# Altium schematic sheet Schematic.SchDoc(Schematic)
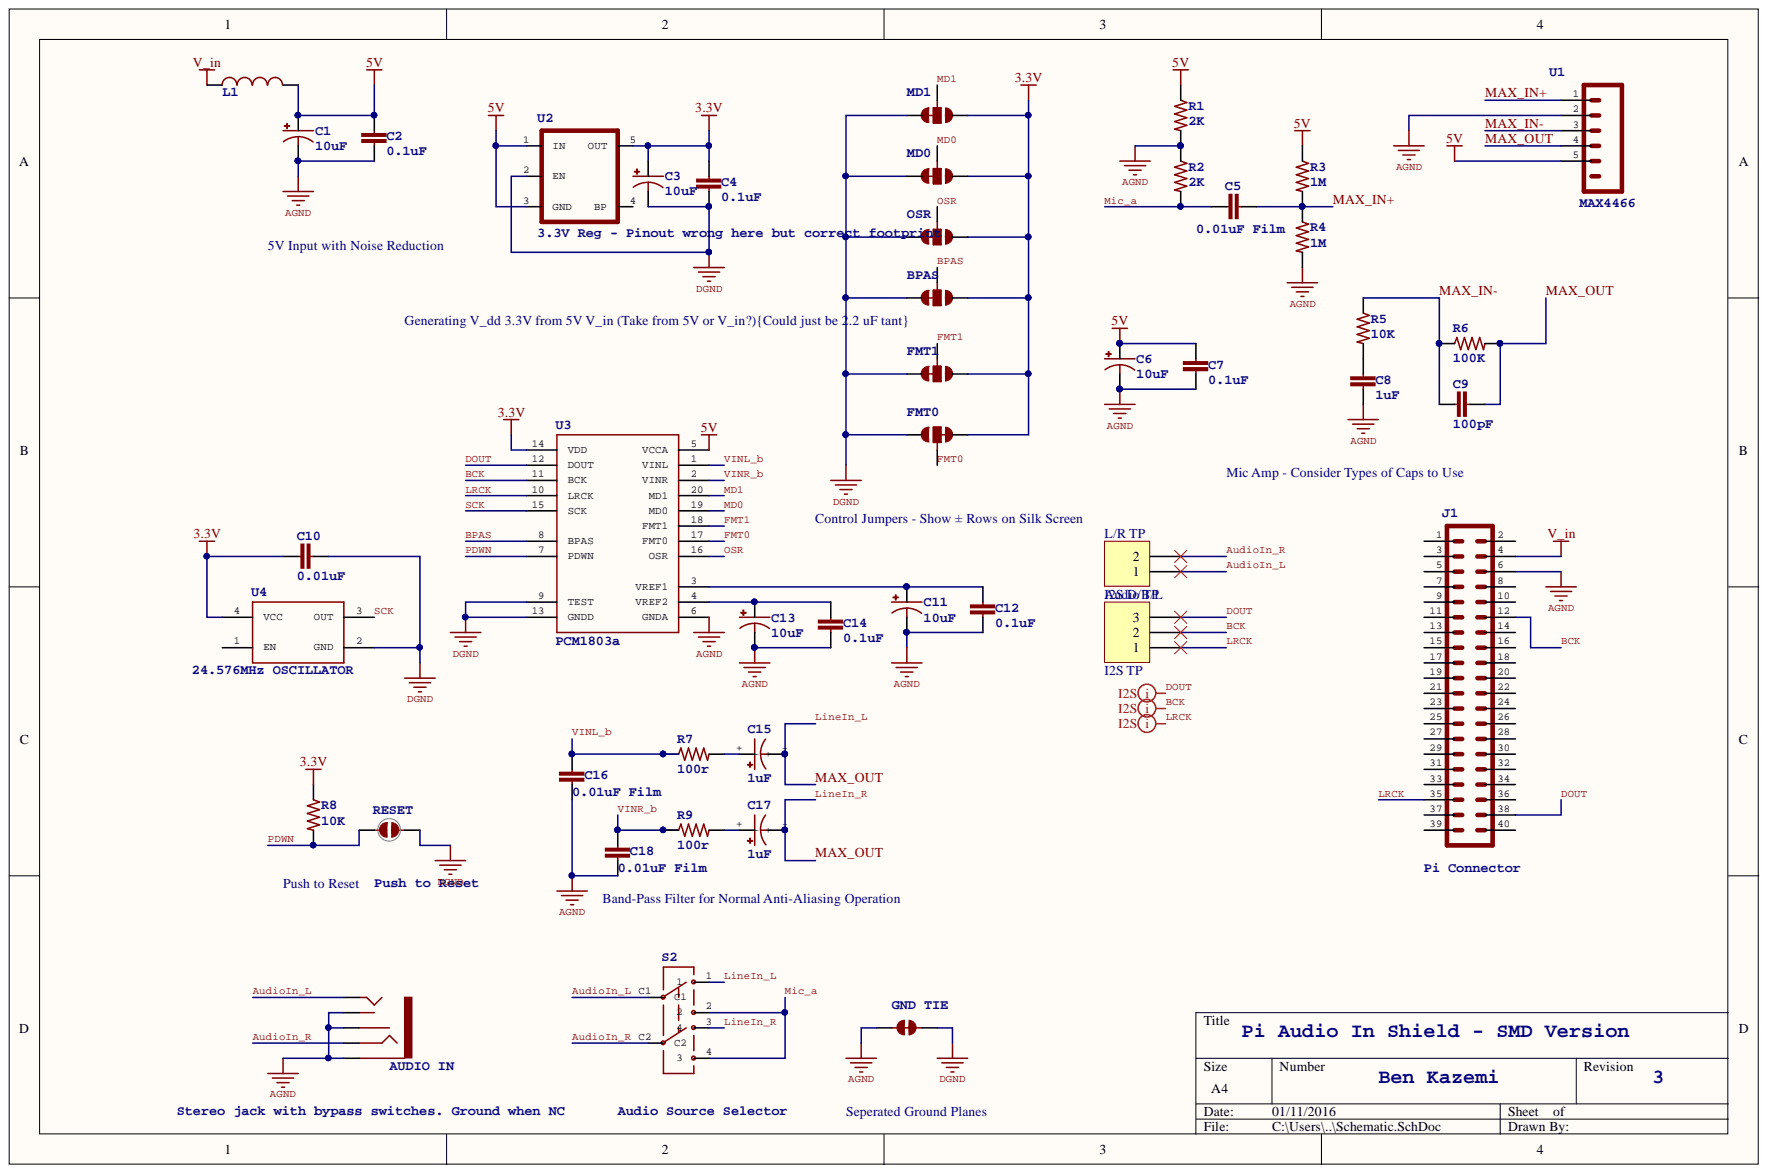
[diagram p0: Schematic.SchDoc]

## Parts
AUDIO_IN  Stereo jack with bypass switches. Ground when NC  [AUDIO-JACK]
BPAS  (no value)  [PAD-JUMPER-3-2OF3_NC_BY_TRACE_YES_SILK_FULL_BOX]
C1  10uF  [EIA3216]
C2  0.1uF  [0603-CAP]
C3  10uF  [EIA3216]
C4  0.1uF  [0603-CAP]
C5  0.01uF Film  [WIMA PCM 10]
C6  10uF  [EIA3216]
C7  0.1uF  [0603-CAP]
C8  1uF  [0603-CAP]
C9  100pF  [0603-CAP]
C10  0.01uF  [0603-CAP]
C11  10uF  [EIA3216]
C12  0.1uF  [0603-CAP]
C13  10uF  [EIA3216]
C14  0.1uF  [0603-CAP]
C15  1uF  [PANASONIC_B_ELECTRO]
C16  0.01uF Film  [WIMA PCM 10]
C17  1uF  [PANASONIC_B_ELECTRO]
C18  0.01uF Film  [WIMA PCM 10]
FMT0  (no value)  [PAD-JUMPER-3-2OF3_NC_BY_TRACE_YES_SILK_FULL_BOX]
FMT1  (no value)  [PAD-JUMPER-3-2OF3_NC_BY_TRACE_YES_SILK_FULL_BOX]
GND_TIE  JUMPER-PAD-2-NC_BY_TRACE  [PAD-JUMPER-2-NC_BY_TRACE_YES_SILK]  (value not drawn)
I2S_D/B/L  I2S TP  [HDR1X3]
J1  Pi Connector  [2X20]
L1  (no value)  [0805]
L/R_TP  Audio TP  [HDR1X2]
MD0  (no value)  [PAD-JUMPER-3-2OF3_NC_BY_TRACE_YES_SILK_FULL_BOX]
MD1  (no value)  [PAD-JUMPER-3-2OF3_NC_BY_TRACE_YES_SILK_FULL_BOX]
OSR  (no value)  [PAD-JUMPER-3-2OF3_NC_BY_TRACE_YES_SILK_FULL_BOX]
R1  2K  [0805]
R2  2K  [0805]
R3  1M  [0805]
R4  1M  [0805]
R5  10K  [0603-RES]
R6  100K  [0603-RES]
R7  100r  [0805]
R8  10K  [0603-RES]
R9  100r  [0805]
RESET  Push to Reset  [PAD-JUMPER-2-NC_BY_PASTE_YES_SILK_FULL_BOX]
S2  Audio Source Selector  [Switch DPDT MCS221N-2017]
U1  MAX4466  [SC70]
U2  3.3V Reg - Pinout wrong here but correct footprint  [SOT23-5]
U3  PCM1803a  [SSOP20]
U4  24.576MHz OSCILLATOR  [CRYSTAL-SMD-7.5X5.2-6PIN]

## Nets
3.3V: BPAS.1 C3.A C4.1 C10.1 FMT0.3 FMT1.1 MD0.1 MD1.1 OSR.1 R8.1 U2.5(OUT) U3.14(VDD) U4.4(VCC)
5V: C1.A C2.1 C6.A C7.1 L1.2 R1.1 R3.1 U1.5 U2.1(IN) U2.3(GND) U3.5(VCCA)
AGND: AUDIO_IN.RSH AUDIO_IN.SLEEVE AUDIO_IN.TSH C1.C C2.2 C6.C C7.2 C8.2 C11.C C12.2 C13.C C14.2 C16.2 C18.2 GND_TIE.1 J1.6 R1.2 R2.1 R4.2 U1.2 U3.6(GNDA)
DGND: BPAS.3 C3.C C4.2 C10.2 FMT0.1 FMT1.3 GND_TIE.2 MD0.3 MD1.3 OSR.3 RESET.2 U2.2(EN) U3.9(TEST) U3.13(GNDD) U4.2(GND)
V_IN: J1.4 L1.1
AUDIOIN_L: AUDIO_IN.TIP L/R_TP.1 S2.C1
AUDIOIN_R: AUDIO_IN.RING L/R_TP.2 S2.C2
BCK: I2S_D/B/L.2 J1.12 U3.11(BCK)
BPAS: BPAS.2 U3.8(BPAS)
DOUT: I2S_D/B/L.3 J1.38 U3.12(DOUT)
FMT0: FMT0.2 U3.17(FMT0)
FMT1: FMT1.2 U3.18(FMT1)
LINEIN_L: C9.2 C15.- C17.- R6.2 S2.1 S2.3 U1.4
LRCK: I2S_D/B/L.1 J1.35 U3.10(LRCK)
MAX_IN+: C5.2 R3.2 R4.1 U1.1
MAX_IN-: C9.1 R5.1 R6.1 U1.3
MD0: MD0.2 U3.19(MD0)
MD1: MD1.2 U3.20(MD1)
MIC_A: C5.1 R2.2 S2.2 S2.4
OSR: OSR.2 U3.16(OSR)
PDWN: R8.2 RESET.1 U3.7(PDWN)
SCK: U3.15(SCK) U4.3(OUT)
VINL_B: C16.1 R7.1 U3.1(VINL)
VINR_B: C18.1 R9.1 U3.2(VINR)
NetC8_1: C8.1 R5.2
NetC11_A: C11.A C12.1 U3.3(VREF1)
NetC13_A: C13.A C14.1 U3.4(VREF2)
NetC15_+: C15.+ R7.2
NetC17_+: C17.+ R9.2

Not connected: J1 1 2 3 5 7 8 9 10 11 13 14 15 16 17 18 19 20 21 22 23 24 25 26 27 28 29 30 31 32 33 34 36 37 39 40; U2 4(BP); U4 1(EN)

## Library data of the parts
AUDIO_IN = AUDIO-JACK2PTH: PROD_ID: CONN-08774; Component Kind: Standard; Library Name: SparkFun-Connectors.IntLib
BPAS FMT0 FMT1 MD0 MD1 OSR = JUMPER-PAD-3-2OF3_NC_BY_TRACE_SMALL: Component Kind: Net Tie; Description: JUMPER-PAD-3-2OF3_NC_BY_TRACE_SMALL; Library Name: SparkFun-Passives.IntLib
C1 C3 C6 C11 C13 = CAP_POL1206: Capacitor PolarizedThese are standard SMD and PTH capacitors. Normally 10uF, 47uF, and 100uF in electrolytic and tantalum varieties. Always verify the external diameter of the through hole cap, it varies with capacity, voltage, and manufacturer. The EIA devices should be standard.; Component Kind: Standard; Library Name: SparkFun-Capacitors.IntLib
C2 C4 C7-C10 C12 C14 = 0.1UF-25V-5%(0603): PROD_ID: CAP-08604; Component Kind: Standard; Library Name: SparkFun-Capacitors.IntLib
C5 C16 C18 = 0.1UF-50V-20%(PTH)KIT-EZ: CAP-08370; Component Kind: Standard; Library Name: SparkFun-Capacitors.IntLib; PROD_ID: CAP-08370
C15 C17 = CAP_POLC: Capacitor PolarizedThese are standard SMD and PTH capacitors. Normally 10uF, 47uF, and 100uF in electrolytic and tantalum varieties. Always verify the external diameter of the through hole cap, it varies with capacity, voltage, and manufacturer. The EIA devices should be standard.; Component Kind: Standard; Library Name: SparkFun-Capacitors.IntLib
I2S_D/B/L = Header 3: Header, 3-Pin; Component Kind: Standard; LatestRevisionDate: 17-Jul-2002; LatestRevisionNote: Re-released for DXP Platform.; Library Name: Miscellaneous Connectors.IntLib; Signal Integrity: Connector; Publisher: Altium Limited
J1 = M20X2: 0.1" spaced headers; Component Kind: Standard; Library Name: SparkFun-Connectors.IntLib
L1 = INDUCTOR0805: Inductors   Basic Inductor/Choke - 0603 and 1206. Footprints are not proven and vary greatly between part numbers. INDUCTOR POWER 3.3UH 4.0A SMD 0.197" L x 0.197" W x 0.177" H (5.00mm x 5.00mm x 4.50mm); Component Kind: Standard; Library Name: SparkFun-Passives.IntLib
L/R_TP = Header 2: Component Kind: Standard; LatestRevisionDate: 17-Jul-2002; LatestRevisionNote: Re-released for DXP Platform.; Library Name: Miscellaneous Connectors.IntLib; Signal Integrity: Connector; Publisher: Altium Limited
R1-R4 R7 R9 = RESISTOR0805-RES: ResistorBasic schematic elements and footprints for 0603, 1206, and PTH resistors.; Component Kind: Standard; Library Name: SparkFun-Resistors.IntLib
R5 R6 R8 = RESISTOR0603-RES: Component Kind: Standard; Library Name: SparkFun-Passives.IntLib
RESET = JUMPER-PAD-2-NC_BY_PASTEFULL-BOX-SILK: Component Kind: Standard; Library Name: SparkFun-Passives.IntLib
S2 = SWITCH-DPDTPTH1: Component Kind: Standard; Library Name: SparkFun-Electromechanical.IntLib
U1 = MINI-DIN6PTH: Component Kind: Standard; Library Name: SparkFun-Connectors.IntLib
U2 = MIC52053.3V: PROD_ID: VREG-00822; Component Kind: Standard; Library Name: SparkFun-PowerIC.IntLib
U3 = PCM1803SMD: 24-bit ADC; Component Kind: Standard; Library Name: SparkFun-AnalogIC.IntLib
U4 = OSCILLATORSMD6: Component Kind: Standard; Library Name: SparkFun-FreqCtrl.IntLib
GND_TIE = JUMPER-PAD-2-NC_BY_TRACE: Component Kind: Net Tie; Library Name: SparkFun-Passives.IntLib
